# Altium schematic sheet 05_PCIE&SATA.SchDoc
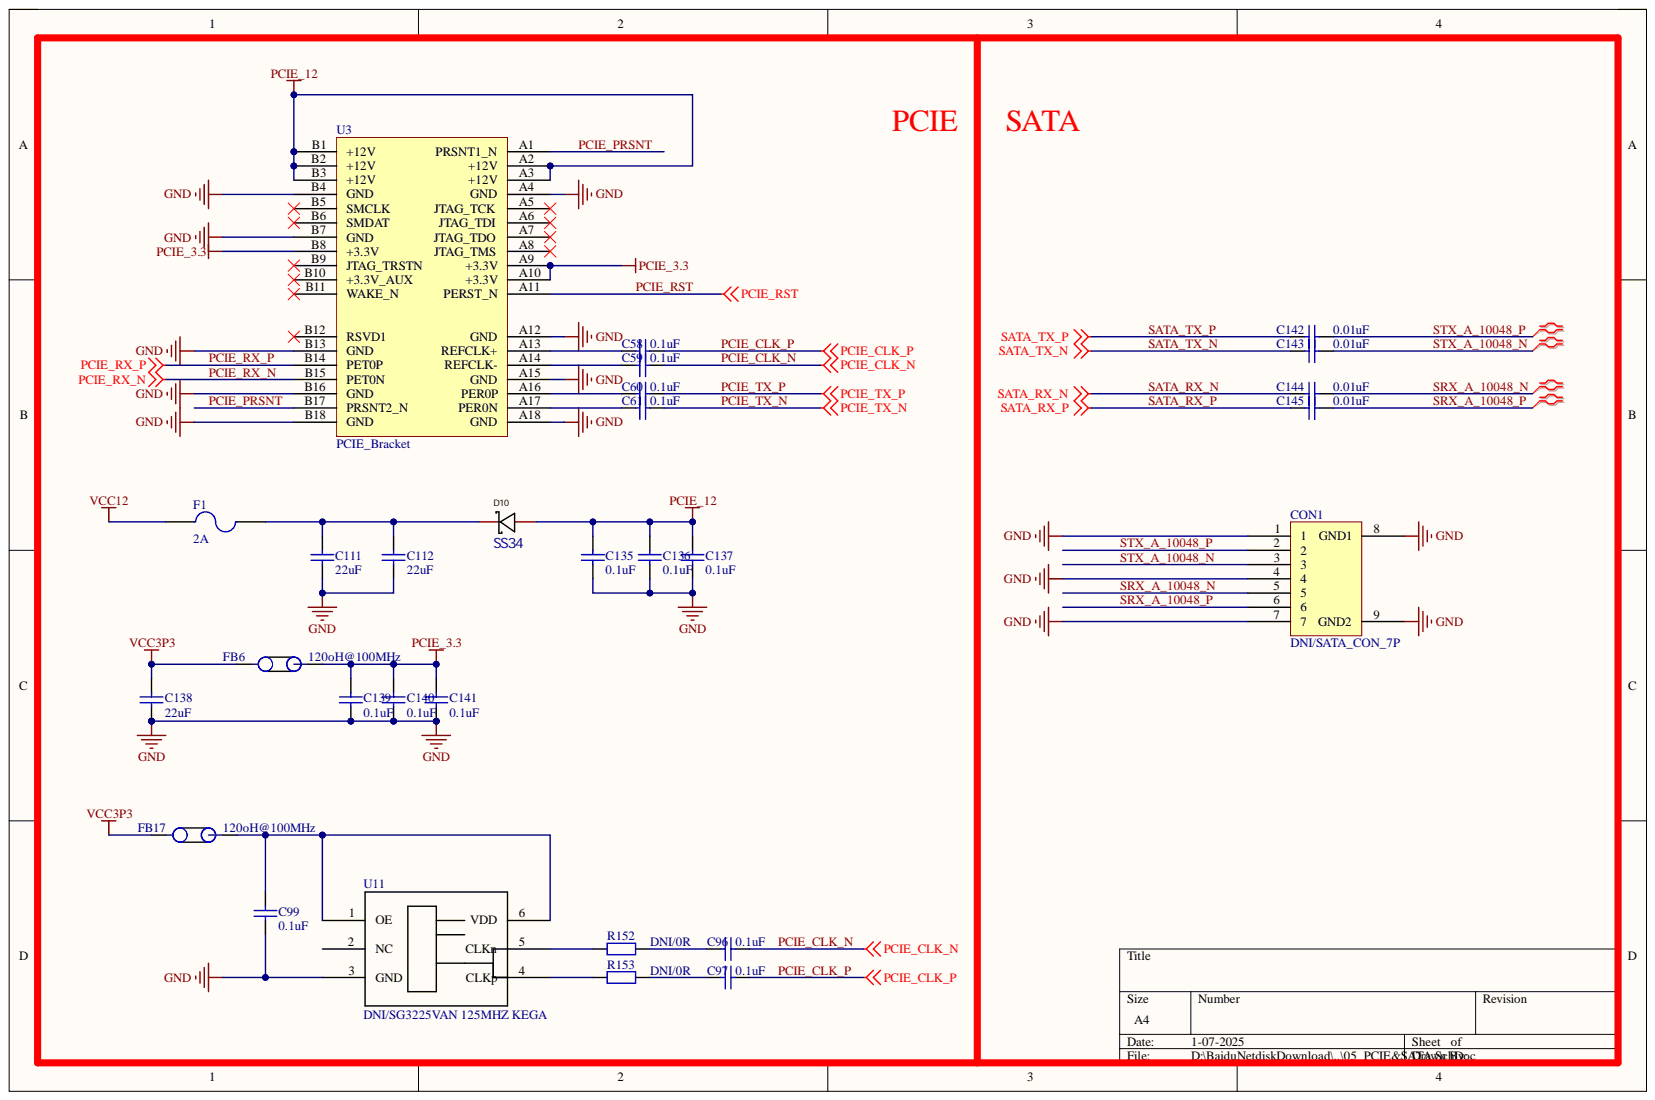
[diagram p0: 05_PCIE&SATA.SchDoc]

## Parts
C58  0.1uF  [C0603]
C59  0.1uF  [C0603]
C60  0.1uF  [C0603]
C61  0.1uF  [C0603]
C96  0.1uF  [C0603]
C97  0.1uF  [C0603]
C99  0.1uF  [C0603]
C111  22uF  [C0805]
C112  22uF  [C0805]
C135  0.1uF  [C0603]
C136  0.1uF  [C0603]
C137  0.1uF  [C0603]
C138  22uF  [C0805]
C139  0.1uF  [C0603]
C140  0.1uF  [C0603]
C141  0.1uF  [C0603]
C142  0.01uF  [C0603]
C143  0.01uF  [C0603]
C144  0.01uF  [C0603]
C145  0.01uF  [C0603]
CON1  DNI/SATA_CON_7P  [sata_7p_50_2hold_winwin_lf3]
D10  SS34  [DO-214AC]
F1  2A  [1812]
FB6  120oH@100MHz  [L0603]
FB17  120oH@100MHz  [L0603]
R152  DNI/0R  [0603R]
R153  DNI/0R  [0603R]
U3  PCIE_Bracket  [PCIX-1PORT]
U11  DNI/SG3225VAN 125MHZ KEGA  [TCXO3225_6P]

## Nets
GND: C99.2 C111.2 C112.2 C135.2 C136.2 C137.2 C138.2 C139.2 C140.2 C141.2 CON1.1 CON1.4 CON1.7 CON1.8(GND1) CON1.9(GND2) U3.A4(GND) U3.A12(GND) U3.A15(GND) U3.A18(GND) U3.B4(GND) U3.B7(GND) U3.B13(GND) U3.B16(GND) U3.B18(GND) U11.3(GND)
PCIE_3.3: C139.1 C140.1 C141.1 FB6.2 U3.A9(+3.3V) U3.A10(+3.3V) U3.B8(+3.3V)
PCIE_12: C135.1 C136.1 C137.1 D10.1 U3.A2(+12V) U3.A3(+12V) U3.B1(+12V) U3.B2(+12V) U3.B3(+12V)
VCC3P3: C138.1 FB6.1 FB17.1
VCC12: F1.1
PCIE_CLK_N: C59.1 C96.1
PCIE_CLK_P: C58.1 C97.1
PCIE_PRSNT: U3.A1(PRSNT1_N) U3.B17(PRSNT2_N)
PCIE_RST: U3.A11(PERST_N)
PCIE_RX_N: U3.B15(PET0N)
PCIE_RX_P: U3.B14(PET0P)
PCIE_TX_N: C61.1
PCIE_TX_P: C60.1
SATA_RX_N: C144.2
SATA_RX_P: C145.2
SATA_TX_N: C143.2
SATA_TX_P: C142.2
SRX_A_10048_N: C144.1 CON1.5
SRX_A_10048_P: C145.1 CON1.6
STX_A_10048_N: C143.1 CON1.3
STX_A_10048_P: C142.1 CON1.2
NetC58_2: C58.2 U3.A13(REFCLK+)
NetC59_2: C59.2 U3.A14(REFCLK-)
NetC60_2: C60.2 U3.A16(PER0P)
NetC61_2: C61.2 U3.A17(PER0N)
NetC96_2: C96.2 R152.1
NetC97_2: C97.2 R153.1
NetC99_1: C99.1 FB17.2 U11.1(OE) U11.6(VDD)
NetC111_1: C111.1 C112.1 D10.2 F1.2
NetR152_2: R152.2 U11.5(CLKn)
NetR153_2: R153.2 U11.4(CLKp)

Not connected: U3 A5(JTAG_TCK) A6(JTAG_TDI) A7(JTAG_TDO) A8(JTAG_TMS) B5(SMCLK) B6(SMDAT) B9(JTAG_TRSTN) B10(+3.3V_AUX) B11(WAKE_N) B12(RSVD1); U11 2(NC)

## Library data of the parts
C58-C61 C96 C97 C111 C112 C138 C142-C145 = Cap: Capacitor; Component Kind: Standard; LatestRevisionDate: 17-Jul-2002; LatestRevisionNote: Re-released for DXP Platform.; Library Name: Miscellaneous Devices.IntLib; PackageDescription: Radial Cap, Thru-Hole; 2 Leads; 0.3 in Pin Spacing; PackageReference: RAD-0.3; Published: 8-Jun-2000; Signal Integrity: Cap; Simulation: CAP; Publisher: Altium Limited
  C58-C61 C96 C97: Value: 0.1uF
  C111 C112 C138: Value: 22uF
  C142-C145: Value: 0.01uF
C99 C135-C137 C139-C141 = Cap_1: Capacitor; Component Kind: Standard; LatestRevisionDate: 17-Jul-2002; LatestRevisionNote: Re-released for DXP Platform.; Library Name: zynq.SCHLIB; PackageDescription: Radial Cap, Thru-Hole; 2 Leads; 0.3 in Pin Spacing; PackageReference: RAD-0.3; Published: 8-Jun-2000; Signal Integrity: Cap; Simulation: CAP; Value: 0.1uF; Publisher: Altium Limited
CON1 = SATA_CON_7P: Component Kind: Standard; Description: SATA_CON_7P; Library Name: Schlib1.SchLib
D10 = SS24_C115726: Manufacturer: 晶导微电子; Manufacturer Part: SS24; Add into BOM: yes; Average Rectified Current (Io): 2A; Forward Voltage (Vf@If): 500mV@2A; Reverse Voltage (Vr): 40V; Component Kind: Standard; Convert to PCB: yes; Datasheet: https://atta.szlcsc.com/upload/public/pdf/source/20170630/1498816629706.pdf; Device: SS24_C115726; JLCPCB Part Class: Extended Part; LCSC Part Name: 40V 2A 500mV@2A; Library Name: 7015.SchLib; Name: SS24; PCB3D: SMA_L4.4-W2.6-LS5.0-RD; Supplier: LCSC; Supplier Footprint: SMA(DO-214AC); Supplier Part: C115726; Symbol: SS24_C115726
F1 = Fuse 2: Fuse; Component Kind: Standard; LatestRevisionDate: 17-Jul-2002; LatestRevisionNote: Re-released for DXP Platform.; Library Name: ac609-V1_2.IntLib; PackageDescription: Thru-Hole; 2 Leads; PackageReference: PIN-W2/E2.8; Published: 8-Jun-2000; Publisher: Altium Limited
FB6 FB17 = CiZhu: Component Kind: Standard; Description: CiZhu; Library Name: zynq.SCHLIB; Value: 120oH@100MHz
R152 R153 = Res2: Resistor; Component Kind: Standard; LatestRevisionDate: 17-Jul-2002; LatestRevisionNote: Re-released for DXP Platform.; PackageReference: AXIAL-0.4; Published: 8-Jun-2000; Value: DNI/0R; Library Name: 元件封装库.pcblib; Publisher: Altium Limited
U11 = DIFF: SG3225VAN 125MHZ KEGA; Component Kind: Standard; Library Name: 7015.SchLib
U3 = PCIE_Bracket: Component Kind: Standard; Description: PCIE_Bracket; Library Name: 7015.SchLib
